# Altium schematic sheet Processor.SchDoc(Processor)
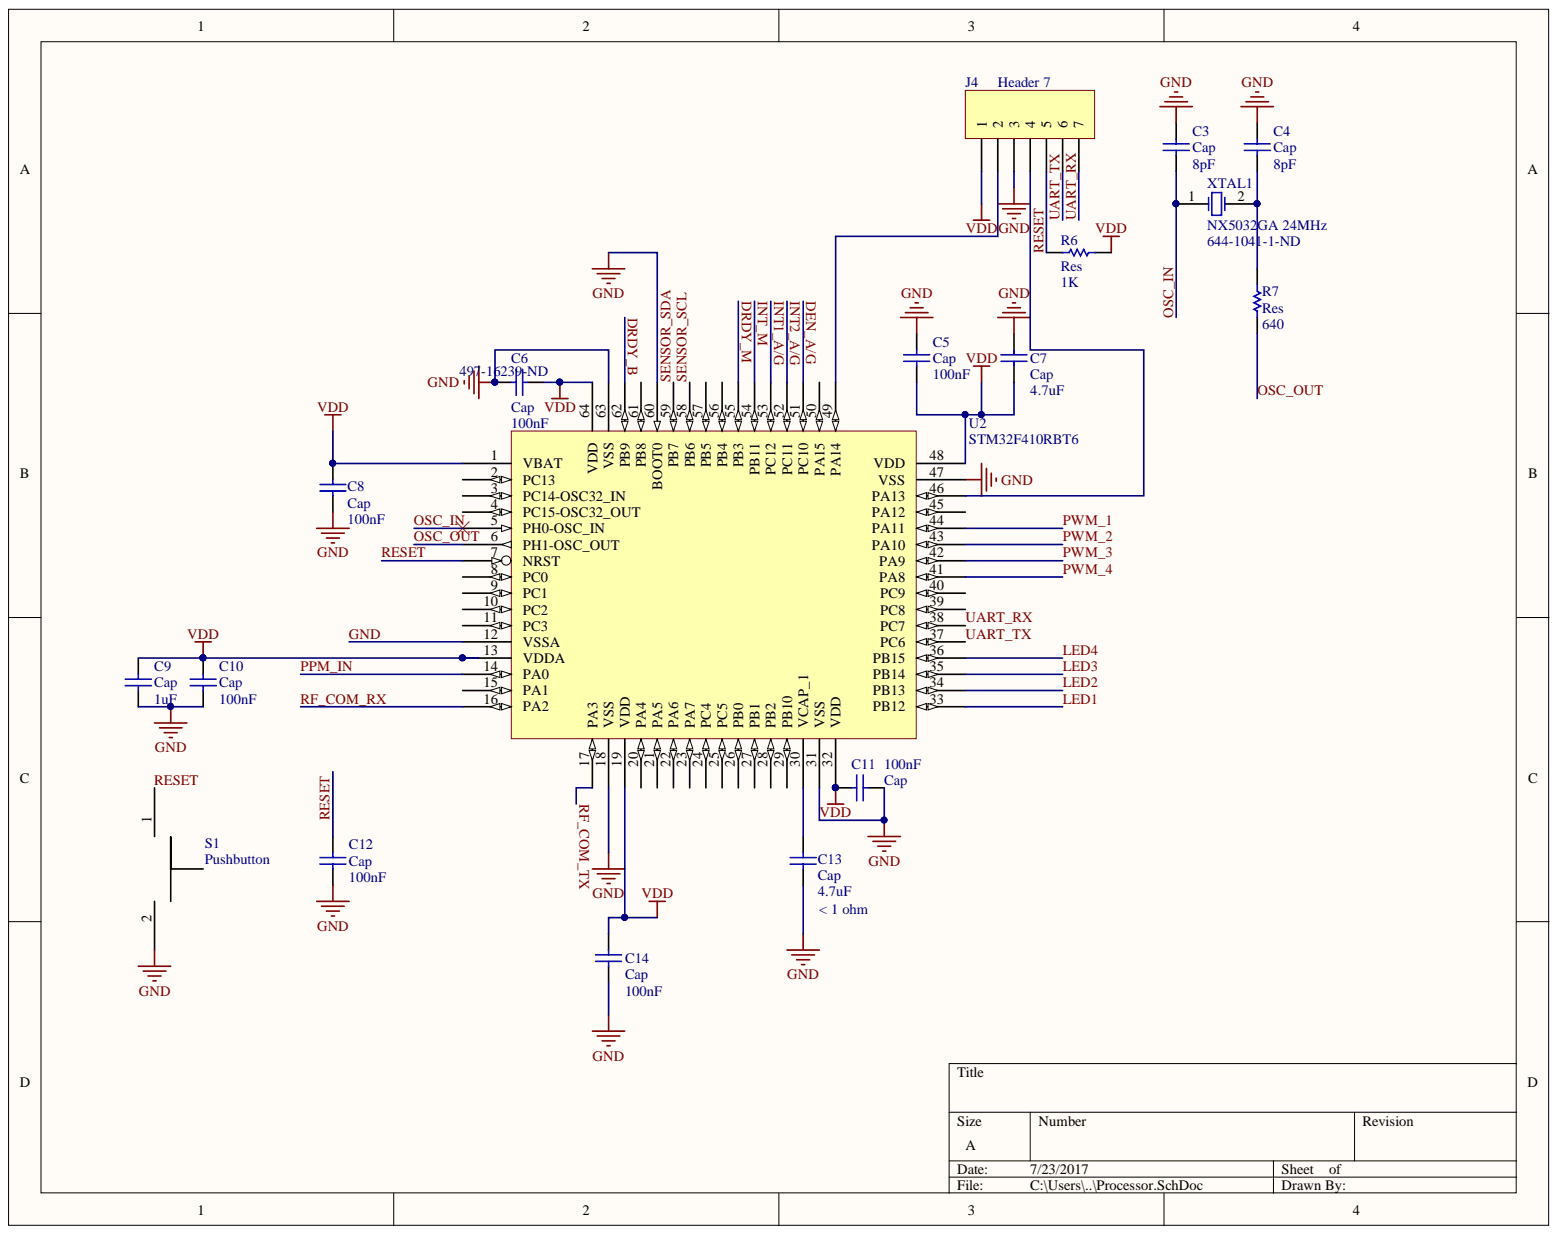
[diagram p0: Processor.SchDoc]

## Parts
C3  Cap  value 8pF  [C0805]
C4  Cap  value 8pF  [C0805]
C5  Cap  value 100nF  [C0805]
C6  Cap  value 100nF  [C0805]
C7  Cap  value 4.7uF  [C0805]
C8  Cap  value 100nF  [C0805]
C9  Cap  value 1uF  [C0805]
C10  Cap  value 100nF  [C0805]
C11  Cap  value 100nF  [C0805]
C12  Cap  value 100nF  [C0805]
C13  Cap  value 4.7uF  [C0805]
C14  Cap  value 100nF  [C0805]
J4  Header 7  [HDR1X7]
R6  Res  value 1K  [3216[1206]]
R7  Res  value 640  [3216[1206]]
S1  Pushbutton  [TactileSwitch]
U2  STM32F410RBT6  Supplier Part Number 1: 497-16239-ND  [LQFP-64 10x10mm]
XTAL1  NX5032GA 24MHz  [NX5032GA]

## Nets
VDD: C5.1 C6.2 C7.1 C8.1 C9.1 C10.1 C11.1 C14.2 J4.1 R6.2 U2.1(VBAT) U2.13(VDDA) U2.19(VDD) U2.32(VDD) U2.48(VDD) U2.64(VDD)
DEN_A/G: U2.51(PC10)
DRDY_B: U2.62(PB9)
DRDY_M: U2.55(PB3)
GND: C3.1 C4.1 C5.2 C6.1 C7.2 C8.2 C9.2 C10.2 C11.2 C12.2 C13.1 C14.1 J4.3 S1.2 U2.12(VSSA) U2.18(VSS) U2.31(VSS) U2.47(VSS) U2.60(BOOT0) U2.63(VSS)
INT1_A/G: U2.53(PC12)
INT2_A/G: U2.52(PC11)
INT_M: U2.54(PB11)
LED1: U2.33(PB12)
LED2: U2.34(PB13)
LED3: U2.35(PB14)
LED4: U2.36(PB15)
OSC_IN: C3.2 U2.5(PH0-OSC_IN) XTAL1.1
OSC_OUT: R7.1 U2.6(PH1-OSC_OUT)
PPM_IN: U2.14(PA0)
PWM_1: U2.44(PA11)
PWM_2: U2.43(PA10)
PWM_3: U2.42(PA9)
PWM_4: U2.41(PA8)
RESET: C12.1 J4.5 R6.1 S1.1 U2.7(NRST)
RF_COM_RX: U2.16(PA2)
RF_COM_TX: U2.17(PA3)
SENSOR_SCL: U2.58(PB6)
SENSOR_SDA: U2.59(PB7)
UART_RX: J4.7 U2.38(PC7)
UART_TX: J4.6 U2.37(PC6)
NetC4_2: C4.2 R7.2 XTAL1.2
NetC13_2: C13.2 U2.30(VCAP_1)
NetJ4_2: J4.2 U2.49(PA14)
NetJ4_4: J4.4 U2.46(PA13)

Not connected: U2 2(PC13) 3(PC14-OSC32_IN) 4(PC15-OSC32_OUT) 8(PC0) 9(PC1) 10(PC2) 11(PC3) 15(PA1) 20(PA4) 21(PA5) 22(PA6) 23(PA7) 24(PC4) 25(PC5) 26(PB0) 27(PB1) 28(PB2) 29(PB10) 39(PC8) 40(PC9) 45(PA12) 50(PA15) 56(PB4) 57(PB5) 61(PB8)

## Library data of the parts
C3-C5 C7-C12 C14 = Cap: Capacitor; Published: 8-Jun-2000; PackageReference: C1206; Library Name: Capacitors.IntLib; LatestRevisionNote: Re-released for DXP Platform.; LatestRevisionDate: 17-Jul-2002; Component Kind: Standard; Publisher: Altium Limited
C6 = Cap: Capacitor; Value: 100nF; Published: 8-Jun-2000; PackageReference: C1206; Library Name: Capacitors.IntLib; LatestRevisionNote: Re-released for DXP Platform.; LatestRevisionDate: 17-Jul-2002; Component Kind: Standard; Publisher: Altium Limited
C13 = Cap: Capacitor; ESR: < 1 ohm; Published: 8-Jun-2000; PackageReference: C1206; Library Name: Capacitors.IntLib; LatestRevisionNote: Re-released for DXP Platform.; LatestRevisionDate: 17-Jul-2002; Component Kind: Standard; Publisher: Altium Limited
J4 = Header 7: Header, 7-Pin; Library Name: Connectors and Headers.IntLib; LatestRevisionNote: Re-released for DXP Platform.; LatestRevisionDate: 17-Jul-2002; Component Kind: Standard; Publisher: Altium Limited
R6 R7 = Res: Surface Mount Resistor; Published: 8-Jun-2000; PackageReference: AXIAL-0.5; Library Name: Resistors.IntLib; LatestRevisionNote: Re-released for DXP Platform.; LatestRevisionDate: 17-Jul-2002; Component Kind: Standard; Publisher: Altium Limited
U2 = STM32F410RB_PINOUT: 64-LQFP - Pinout Representation; Manufacturer: STMicroelectronics; RAM Size: 32; Peripherals: Brown-out Detect/Reset,POR,PWM,WDT,DMA; Oscillator Type: Internal; Number of I/Os: 50; Min Supply Voltage: 1.7; Memory Type: FLASH; Memory Size: 128; Max Supply Voltage: 3.6; Interface: I2C,SPI,IrDA,UART,USART,LIN; Frequency: 100; Supplier 1: Digikey; REACH SVHC: No SVHC; Pricing 2: 1=4.4, 10=3.951, 100=3.237, 500=2.7556, 1000=2.324 (USD); Packaging: Tray; Number of Pins: 64; Min Operating Temperature: -40; Max Operating Temperature: 85; Manufacturer Part Number: STM32F410RBT6; Library Name: FC.SchLib; Lead Free: Lead Free; ComponentLink1URL: http://www.farnell.com/datasheets/2006536.pdf; ComponentLink1Description: http://www.farnell.com/datasheets/2006536.pdf; Component Kind: Standard; Case/Package: LQFP
XTAL1 = NX5032GA: Manufacturer Part Number: NX5032GA-25.000000MHZ-LN-CD-1; Manufacturer: Nihon Dempa Kogyo (NDK); Load Capacitance: 8; Frequency Tolerance: 50; Frequency: 25; ESR (Equivalent Series Resistance): 70; Width: 0.126; Supplier Part Number 1: 644-1041-1-ND; Supplier 1: Digikey; Stock 1: 128038; Pricing 1: 1=0.66, 10=0.55, 50=0.495, 100=0.44, 500=0.418 (USD); Packaging: Tape and Reel; Package Quantity: 1000; Number of Pins: 2; Min Operating Temperature: -10; Max Operating Temperature: 70; Library Name: FC.SchLib; Length: 0.197; Height: 0.059; Frequency Stability: 50; Description: NX5032GA; ComponentLink3URL: https://datasheet.ciiva.com/856/nx5032ga-856799.pdf; ComponentLink3Description: https://datasheet.ciiva.com/856/nx5032ga-856799.pdf; ComponentLink2URL: https://datasheet.ciiva.com/856/nx5032ga-856798.pdf; ComponentLink2Description: https://datasheet.ciiva.com/856/nx5032ga-856798.pdf; ComponentLink1URL: https://datasheet.ciiva.com/856/nx5032ga-856797.pdf; ComponentLink1Description: https://datasheet.ciiva.com/856/nx5032ga-856797.pdf; Component Kind: Standard; Case/Package: SMD/SMT
S1 = Pushbutton: Library Name: FC.SchLib; Component Kind: Standard
